# Altium schematic sheet PAGE4-RS485.SchDoc
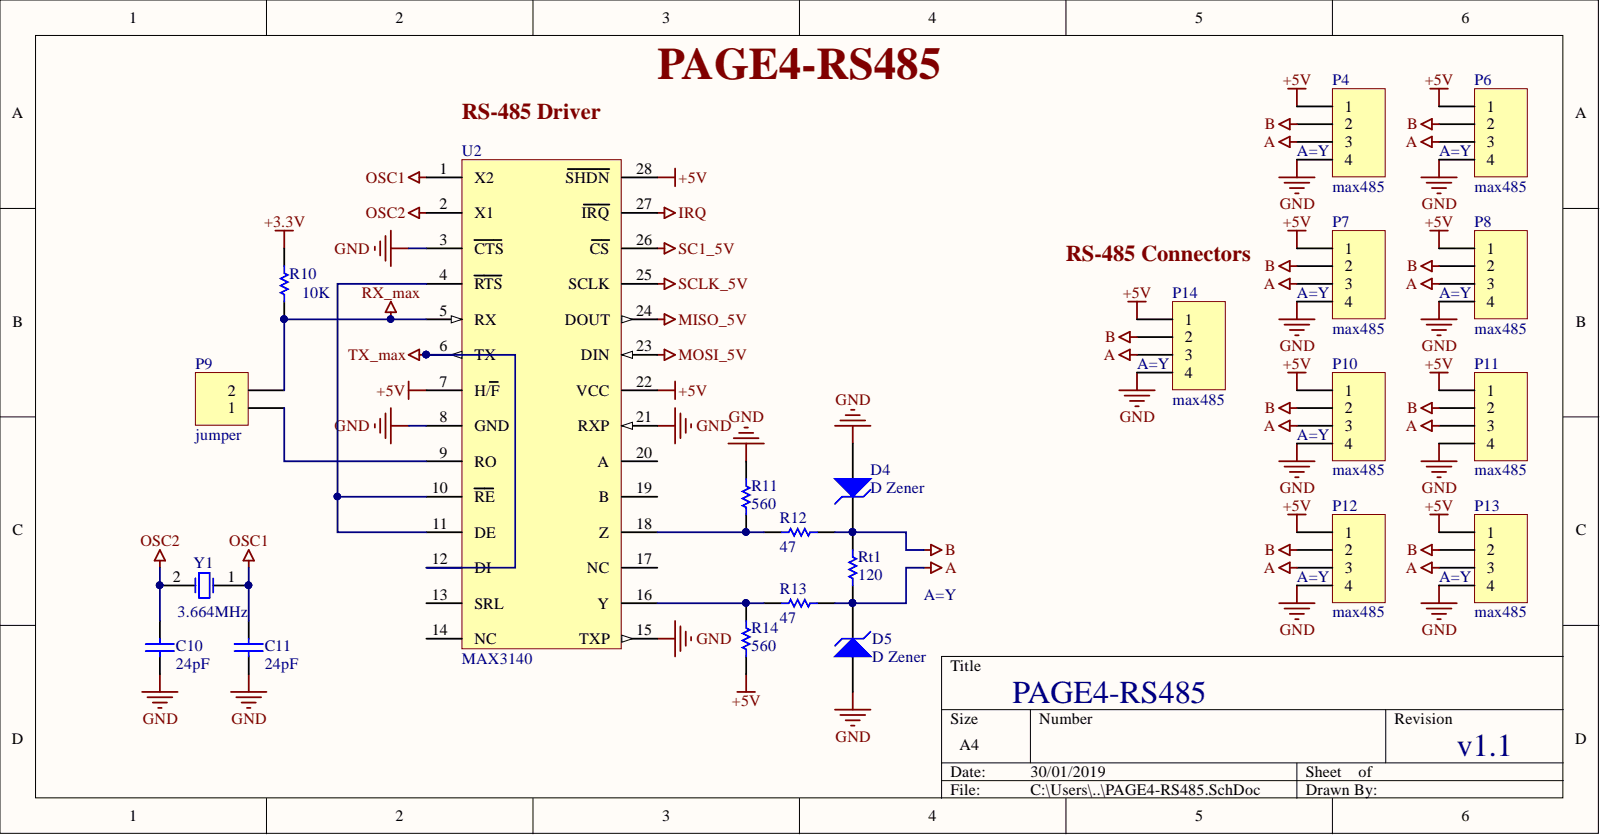
[diagram p0: PAGE4-RS485.SchDoc]

## Parts
C10  value 24pF  [1206_cap]  (hidden comment: cap_smd1206)
C11  value 24pF  [1206_cap]  (hidden comment: cap_smd1206)
D4  D Zener  [DSO-C2/X3.3]
D5  D Zener  [DSO-C2/X3.3]
P4  max485  [HDR_1X4]
P6  max485  [HDR_1X4]
P7  max485  [HDR_1X4]
P8  max485  [HDR_1X4]
P9  jumper  [HDR_1X2]
P10  max485  [HDR_1X4]
P11  max485  [HDR_1X4]
P12  max485  [HDR_1X4]
P13  max485  [HDR_1X4]
P14  max485  [HDR_1X4]
R10  value 10K  [1206_res]  (hidden comment: res)
R11  value 560  [1206_res]  (hidden comment: res)
R12  value 47  [1206_res]  (hidden comment: res)
R13  value 47  [1206_res]  (hidden comment: res)
R14  value 560  [1206_res]  (hidden comment: res)
Rt1  value 120  [1206_res]  (hidden comment: res)
U2  MAX3140  [QSOP-28]
Y1  3.664MHz  [XtalSmd]

## Nets
+3.3V: R10.2
+5V: P4.1 P6.1 P7.1 P8.1 P10.1 P11.1 P12.1 P13.1 P14.1 R14.1 U2.7(H/F) U2.22(VCC) U2.28(SHDN)
A: D5.2 P4.3 P6.3 P7.3 P8.3 P10.3 P11.3 P12.3 P13.3 P14.3 R13.1 Rt1.2
B: D4.2 P4.2 P6.2 P7.2 P8.2 P10.2 P11.2 P12.2 P13.2 P14.2 R12.1 Rt1.1
GND: C10.1 C11.1 D4.1 D5.1 P4.4 P6.4 P7.4 P8.4 P10.4 P11.4 P12.4 P13.4 P14.4 R11.2 U2.3(CTS) U2.8(GND) U2.15(TXP) U2.21(RXP)
IRQ: U2.27(IRQ)
MISO_5V: U2.24(DOUT)
MOSI_5V: U2.23(DIN)
OSC1: C11.2 U2.1(X2) Y1.1
OSC2: C10.2 U2.2(X1) Y1.2
RX_MAX: P9.2 R10.1 U2.5(RX)
SC1_5V: U2.26(CS)
SCLK_5V: U2.25(SCLK)
TX_MAX: U2.6(TX) U2.12(DI)
NetP9_1: P9.1 U2.9(RO)
NetR11_1: R11.1 R12.2 U2.18(Z)
NetR13_2: R13.2 R14.2 U2.16(Y)
NetU2_4: U2.4(RTS) U2.10(RE) U2.11(DE)

Not connected: U2 13(SRL) 14(NC) 17(NC) 19(B) 20(A)

## Library data of the parts
C10 C11 = cap_smd1206: Capacitor; Component Kind: Standard; LatestRevisionDate: 17-Jul-2002; LatestRevisionNote: Re-released for DXP Platform.; PackageReference: RAD-0.3; Published: 8-Jun-2000; Signal Integrity: Cap; Simulation: CAP; Library Name: hepek_lib.SchLib; Publisher: Altium Limited
D4 D5 = D Zener: Zener Diode; Component Kind: Standard; LatestRevisionDate: 17-Jul-2002; LatestRevisionNote: Re-released for DXP Platform.; PackageReference: DIODE-0.7; Published: 8-Jun-2000; Signal Integrity: D Zener; Simulation: ZENER; Library Name: hepek_lib.SchLib; Publisher: Altium Limited
P4 P6-P8 P10-P14 = header_4: Header, 4-Pin; Component Kind: Standard; LatestRevisionDate: 17-Jul-2002; LatestRevisionNote: Re-released for DXP Platform.; Signal Integrity: Connector; Library Name: hepek_lib.SchLib; Publisher: Altium Limited
P9 = header_2: Header, 2-Pin; Component Kind: Standard; LatestRevisionDate: 17-Jul-2002; LatestRevisionNote: Re-released for DXP Platform.; Signal Integrity: Connector; Library Name: hepek_lib.SchLib; Publisher: Altium Limited
R10-R14 Rt1 = res: Resistor; Component Kind: Standard; LatestRevisionDate: 17-Jul-2002; LatestRevisionNote: Re-released for DXP Platform.; PackageReference: AXIAL-0.3; Published: 8-Jun-2000; Signal Integrity: Res; Simulation: RESISTOR; Library Name: hepek_lib.SchLib; Publisher: Altium Limited
U2 = MAX3140: SPI/MICROWIRE-Compatible UART with Integrated  True Fail-Safe RS-485/RS-422 Transceivers; Code_IPC: SOP65P780-28; Code_JEDEC: MO-150-AH; Component Kind: Standard; ComponentLink1Description: Manufacturer Link; ComponentLink1URL: http://www.maxim-ic.com/; ComponentLink2Description: Datasheet; ComponentLink2URL: http://pdfserv.maxim-ic.com/en/ds/MAX3222-MAX3241.pdf; DatasheetVersion: Mar-1999; LatestRevisionDate: 29-May-2009; LatestRevisionNote: IPC-7351 Footprint Added.; PackageDescription: 28-Pin Small Outline Package 0.65 mm Pitch; PackageReference: SSOP28; PackageVersion: Jul-2000; PCB3D: SSOP28; Published: 16-Jan-2001; Library Name: hepek_lib.SchLib; Publisher: Altium Limited
Y1 = XtalSmd: Crystal Oscillator; Component Kind: Standard; LatestRevisionDate: 17-Jul-2002; LatestRevisionNote: Re-released for DXP Platform.; PackageReference: R38; Published: 8-Jun-2000; Simulation: XTAL; Library Name: hepek_lib.SchLib; Publisher: Altium Limited
